# Altium schematic sheet Droplet_SCH.SchDoc(Droplet_SCH)
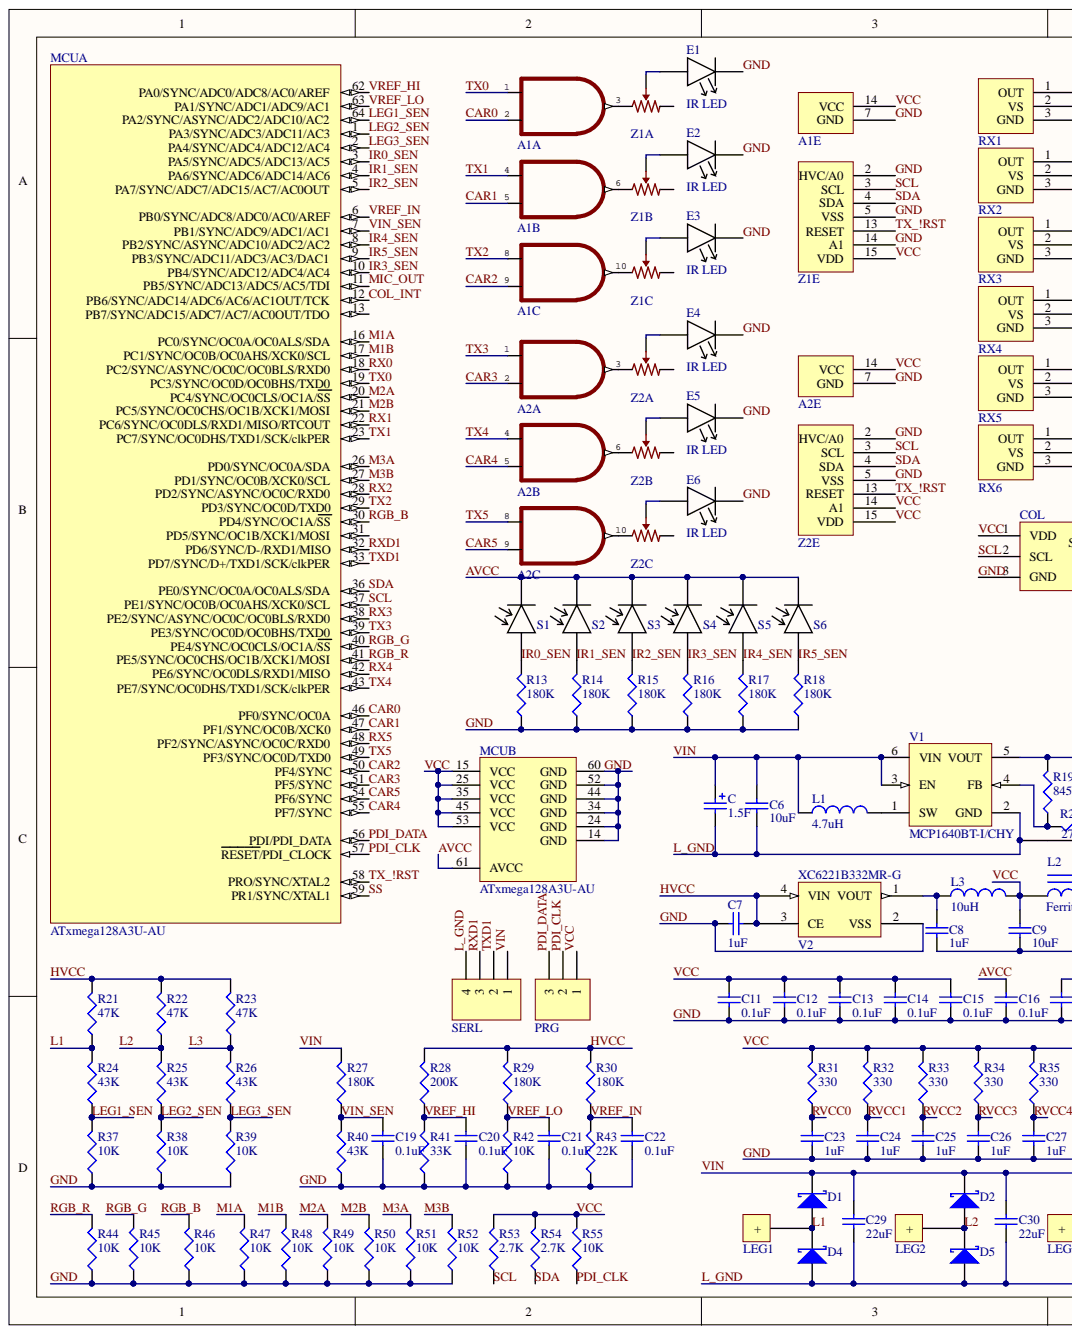
[diagram p0: Droplet_SCH.SchDoc, left]
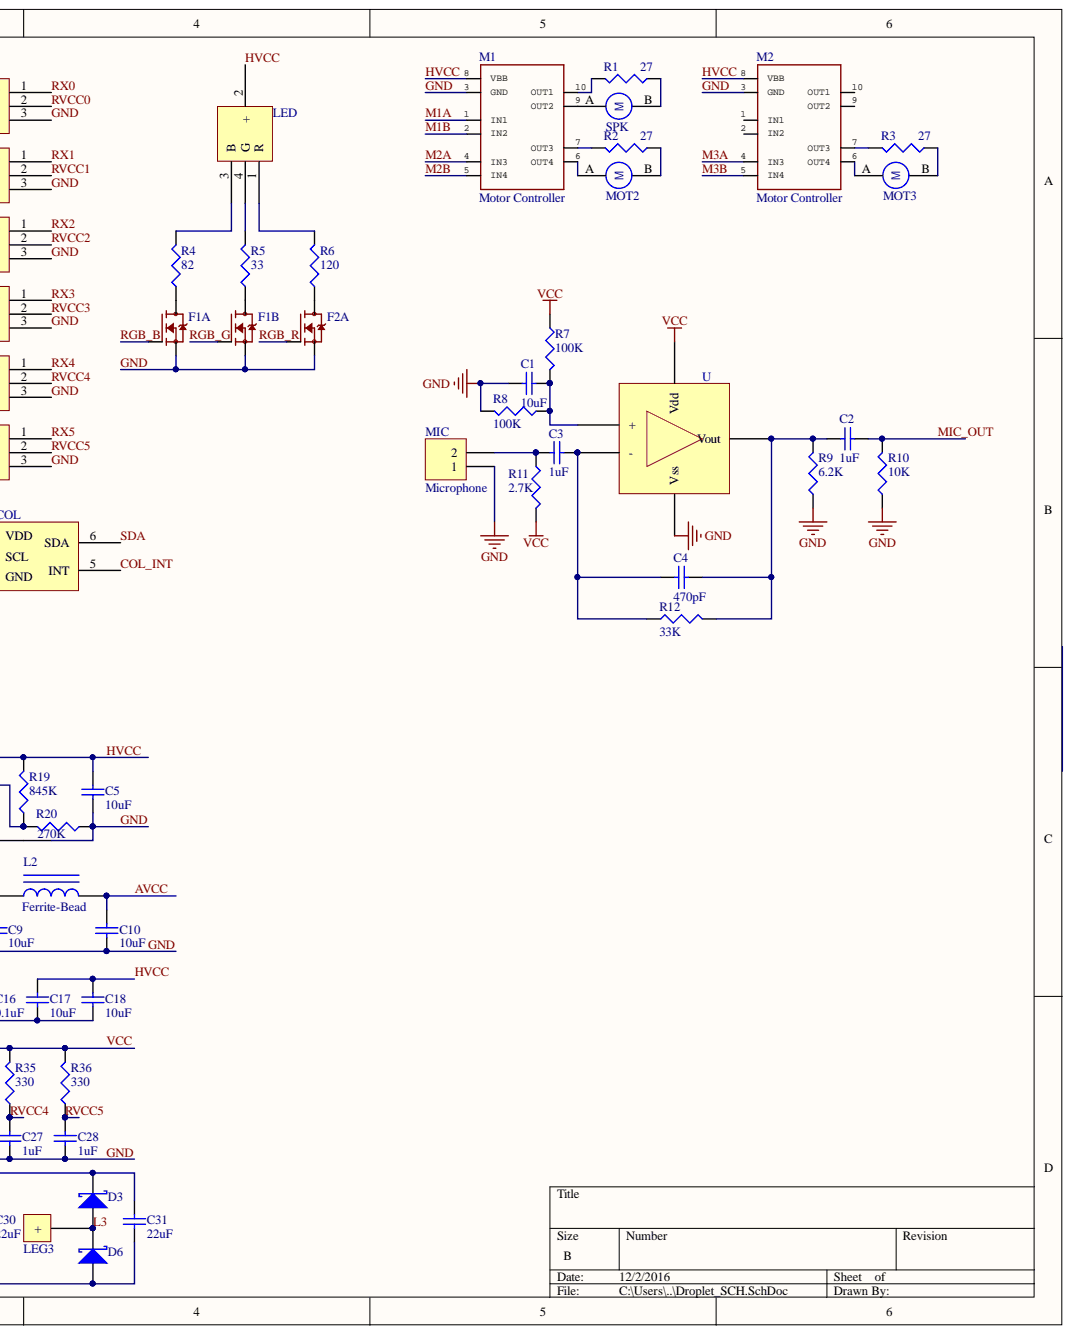
[diagram p1: Droplet_SCH.SchDoc, right]

## Parts
A1  And Gates  [SOT762-1]  (value not drawn)  (parts A,B,C,E)
A2  And Gates  [SOT762-1]  (value not drawn)  (parts A,B,C,E)
C  1.5F  [SuperCap]
C1  10uF  [1608[0603]]
C2  1uF  [0402]
C3  1uF  [0402]
C4  470pF  [0402]
C5  10uF  [1608[0603]]
C6  10uF  [1608[0603]]
C7  1uF  [0402]
C8  1uF  [0402]
C9  10uF  [1608[0603]]
C10  10uF  [1608[0603]]
C11  0.1uF  [0402]
C12  0.1uF  [0402]
C13  0.1uF  [0402]
C14  0.1uF  [0402]
C15  0.1uF  [0402]
C16  0.1uF  [0402]
C17  10uF  [1608[0603]]
C18  10uF  [1608[0603]]
C19  0.1uF  [0402]
C20  0.1uF  [0402]
C21  0.1uF  [0402]
C22  0.1uF  [0402]
C23  1uF  [0402]
C24  1uF  [0402]
C25  1uF  [0402]
C26  1uF  [0402]
C27  1uF  [0402]
C28  1uF  [0402]
C29  22uF  [C1206]
C30  22uF  [C1206]
C31  22uF  [C1206]
COL  Color Sensor  [6SMD-FN]  (value not drawn)
D1  Diode  [Diode]  (value not drawn)
D2  Diode  [Diode]  (value not drawn)
D3  Diode  [Diode]  (value not drawn)
D4  Diode  [Diode]  (value not drawn)
D5  Diode  [Diode]  (value not drawn)
D6  Diode  [Diode]  (value not drawn)
E1  IR LED  [SMD IR RX/TX]
E2  IR LED  [SMD IR RX/TX]
E3  IR LED  [SMD IR RX/TX]
E4  IR LED  [SMD IR RX/TX]
E5  IR LED  [SMD IR RX/TX]
E6  IR LED  [SMD IR RX/TX]
F1  F1  [SOT-363]  (parts A,B)
F2  F2  [SOT-363]  (part A)
GND_<->_L_GND1  Short Circuit  [SHORTCIRCUIT]  (value not drawn)  (no BOM)
L1  4.7uH  [14-1210]
L2  Ferrite-Bead  [1608[0603]]
L3  10uH  [C0805]
LED  RGB LED  [RGB LED]  (value not drawn)
LEG1  Leg  [Wide Leg]  (value not drawn)
LEG2  Leg  [Wide Leg]  (value not drawn)
LEG3  Leg  [Wide Leg]  (value not drawn)
M1  Motor Controller  [EJ 10-PIN DNFN]
M2  Motor Controller  [EJ 10-PIN DNFN]
MCU  ATxmega128A3U-AU  [XMega No Center Pad]  (parts A,B)
MIC  Microphone  [Microphone]
MOT2  Motor  [Motor Pads]  (value not drawn)
MOT3  Motor  [Motor Pads]  (value not drawn)
PRG  Header 3  [3XHEADER_SMT]  (value not drawn)
R1  27  [0402]
R2  27  [0402]
R3  27  [0402]
R4  82  [0402]
R5  33  [0402]
R6  120  [0402]
R7  100K  [0402]
R8  100K  [0402]
R9  6.2K  [0402]
R10  10K  [0402]
R11  2.7K  [0402]
R12  33K  [0402]
R13  180K  [0402]
R14  180K  [0402]
R15  180K  [0402]
R16  180K  [0402]
R17  180K  [0402]
R18  180K  [0402]
R19  845K  [0402]
R20  270K  [0402]
R21  47K  [0402]
R22  47K  [0402]
R23  47K  [0402]
R24  43K  [0402]
R25  43K  [0402]
R26  43K  [0402]
R27  180K  [0402]
R28  200K  [0402]
R29  180K  [0402]
R30  180K  [0402]
R31  330  [0402]
R32  330  [0402]
R33  330  [0402]
R34  330  [0402]
R35  330  [0402]
R36  330  [0402]
R37  10K  [0402]
R38  10K  [0402]
R39  10K  [0402]
R40  43K  [0402]
R41  33K  [0402]
R42  10K  [0402]
R43  22K  [0402]
R44  10K  [0402]
R45  10K  [0402]
R46  10K  [0402]
R47  10K  [0402]
R48  10K  [0402]
R49  10K  [0402]
R50  10K  [0402]
R51  10K  [0402]
R52  10K  [0402]
R53  2.7K  [0402]
R54  2.7K  [0402]
R55  10K  [0402]
RX1  IR Receiver  [IR RECEIVER]  (value not drawn)
RX2  IR Receiver  [IR RECEIVER]  (value not drawn)
RX3  IR Receiver  [IR RECEIVER]  (value not drawn)
RX4  IR Receiver  [IR RECEIVER]  (value not drawn)
RX5  IR Receiver  [IR RECEIVER]  (value not drawn)
RX6  IR Receiver  [IR RECEIVER]  (value not drawn)
S1  IR Sensor  [SMD IR RX/TX]  (value not drawn)
S2  IR Sensor  [SMD IR RX/TX]  (value not drawn)
S3  IR Sensor  [SMD IR RX/TX]  (value not drawn)
S4  IR Sensor  [SMD IR RX/TX]  (value not drawn)
S5  IR Sensor  [SMD IR RX/TX]  (value not drawn)
S6  IR Sensor  [SMD IR RX/TX]  (value not drawn)
SERL  Header 4  [4XHEADER_LONG]  (value not drawn)
SPK  Speaker  [Motor Pads]  (value not drawn)
U  Microchip MCP6001  [OPAMP_SC70]  (value not drawn)
V1  MCP1640BT-I/CHY  [MCHP-SOT-23-CHY6_N]
V2  XC6221B332MR-G  [SOT-25]
Z1  Variable Resistor  [Variable Resistor]  (value not drawn)  (parts A,B,C,E)
Z2  Variable Resistor  [Variable Resistor]  (value not drawn)  (parts A,B,C,E)

## Nets
AVCC: C10.2 C16.2 L2.2 MCU.61(AVCC) S1.2 S2.2 S3.2 S4.2 S5.2 S6.2
CAR0: A1.2 MCU.46(PF0/SYNC/OC0A)
CAR1: A1.5 MCU.47(PF1/SYNC/OC0B/XCK0)
CAR2: A1.9 MCU.50(PF4/SYNC)
CAR3: A2.2 MCU.51(PF5/SYNC)
CAR4: A2.5 MCU.55(PF7/SYNC)
CAR5: A2.9 MCU.54(PF6/SYNC)
COL_INT: COL.5(INT) MCU.12(PB6/SYNC/ADC14/ADC6/AC6/AC1OUT/TCK)
GND: A1.7(GND) A2.7(GND) C1.1 C5.1 C7.1 C8.1 C9.1 C10.1 C11.1 C12.1 C13.1 C14.1 C15.1 C16.1 C17.1 C18.1 C19.1 C20.1 C21.1 C22.1 C23.1 C24.1 C25.1 C26.1 C27.1 C28.1 COL.3(GND) E1.2 E2.2 E3.2 E4.2 E5.2 E6.2 F1.1 F1.4 F2.1 GND_<->_L_GND1.30 M1.3(GND) M2.3(GND) MCU.14(GND) MCU.24(GND) MCU.34(GND) MCU.44(GND) MCU.52(GND) MCU.60(GND) MIC.1 R8.2 R9.1 R10.1 R13.1 R14.1 R15.1 R16.1 R17.1 R18.1 R20.1 R37.1 R38.1 R39.1 R40.1 R41.1 R42.1 R43.1 R44.1 R45.1 R46.1 R47.1 R48.1 R49.1 R50.1 R51.1 R52.1 RX1.3 RX2.3 RX3.3 RX4.3 RX5.3 RX6.3 U.2(Vss) V2.2(VSS) Z1.2(HVC/A0) Z1.5(VSS) Z1.14(A1) Z2.2(HVC/A0) Z2.5(VSS)
HVCC: C5.2 C7.2 C17.2 C18.2 LED.2(+) M1.8(VBB) M2.8(VBB) R19.2 R21.2 R22.2 R23.2 R28.2 R29.2 R30.2 V1.5(VOUT) V2.3(CE) V2.4(VIN)
IR0_SEN: MCU.3(PA5/SYNC/ADC5/ADC13/AC5) R13.2 S1.1
IR1_SEN: MCU.4(PA6/SYNC/ADC6/ADC14/AC6) R14.2 S2.1
IR2_SEN: MCU.5(PA7/SYNC/ADC7/ADC15/AC7/AC0OUT) R15.2 S3.1
IR3_SEN: MCU.10(PB4/SYNC/ADC12/ADC4/AC4) R16.2 S4.1
IR4_SEN: MCU.8(PB2/SYNC/ASYNC/ADC10/ADC2/AC2) R17.2 S5.1
IR5_SEN: MCU.9(PB3/SYNC/ADC11/ADC3/AC3/DAC1) R18.2 S6.1
L1: D1.GND D4.VIN LEG1.1 R21.1 R24.2
L2: D2.GND D5.VIN LEG2.1 R22.1 R25.2
L3: D3.GND D6.VIN LEG3.1 R23.1 R26.2
L_GND: C.GND C6.1 C29.1 C30.1 C31.1 D4.GND D5.GND D6.GND GND_<->_L_GND1.29 SERL.4 V1.2(GND)
LEG1_SEN: MCU.64(PA2/SYNC/ASYNC/ADC2/ADC10/AC2) R24.1 R37.2
LEG2_SEN: MCU.1(PA3/SYNC/ADC3/ADC11/AC3) R25.1 R38.2
LEG3_SEN: MCU.2(PA4/SYNC/ADC4/ADC12/AC4) R26.1 R39.2
M1A: M1.1(IN1) MCU.16(PC0/SYNC/OC0A/OC0ALS/SDA) R47.2
M1B: M1.2(IN2) MCU.17(PC1/SYNC/OC0B/OC0AHS/XCK0/SCL) R48.2
M2A: M1.4(IN3) MCU.20(PC4/SYNC/OC0CLS/OC1A/SS) R49.2
M2B: M1.5(IN4) MCU.21(PC5/SYNC/OC0CHS/OC1B/XCK1/MOSI) R50.2
M3A: M2.4(IN3) MCU.26(PD0/SYNC/OC0A/SDA) R51.2
M3B: M2.5(IN4) MCU.27(PD1/SYNC/OC0B/XCK0/SCL) R52.2
MIC_OUT: C2.2 MCU.11(PB5/SYNC/ADC13/ADC5/AC5/TDI) R10.2
PDI_CLK: MCU.57(RESET/PDI_CLOCK) PRG.2 R55.1
PDI_DATA: MCU.56(PDI/PDI_DATA) PRG.3
RGB_B: F1.2 MCU.30(PD4/SYNC/OC1A/SS) R46.2
RGB_G: F1.5 MCU.40(PE4/SYNC/OC0CLS/OC1A/SS) R45.2
RGB_R: F2.2 MCU.41(PE5/SYNC/OC0CHS/OC1B/XCK1/MOSI) R44.2
RVCC0: C23.2 R31.1 RX1.2
RVCC1: C24.2 R32.1 RX2.2
RVCC2: C25.2 R33.1 RX3.2
RVCC3: C26.2 R34.1 RX4.2
RVCC4: C27.2 R35.1 RX5.2
RVCC5: C28.2 R36.1 RX6.2
RX0: MCU.18(PC2/SYNC/ASYNC/OC0C/OC0BLS/RXD0) RX1.1
RX1: MCU.22(PC6/SYNC/OC0DLS/RXD1/MISO/RTCOUT) RX2.1
RX2: MCU.28(PD2/SYNC/ASYNC/OC0C/RXD0) RX3.1
RX3: MCU.38(PE2/SYNC/ASYNC/OC0C/OC0BLS/RXD0) RX4.1
RX4: MCU.42(PE6/SYNC/OC0DLS/RXD1/MISO) RX5.1
RX5: MCU.48(PF2/SYNC/ASYNC/OC0C/RXD0) RX6.1
RXD1: MCU.32(PD6/SYNC/D-/RXD1/MISO) SERL.3
SCL: COL.2(SCL) MCU.37(PE1/SYNC/OC0B/OC0AHS/XCK0/SCL) R53.1 Z1.3(SCL) Z2.3(SCL)
SDA: COL.6(SDA) MCU.36(PE0/SYNC/OC0A/OC0ALS/SDA) R54.1 Z1.4(SDA) Z2.4(SDA)
SS: MCU.59(PR1/SYNC/XTAL1)
TX0: A1.1 MCU.19(PC3/SYNC/OC0D/OC0BHS/TXD0)
TX1: A1.4 MCU.23(PC7/SYNC/OC0DHS/TXD1/SCK/clkPER)
TX2: A1.8 MCU.29(PD3/SYNC/OC0D/TXD0)
TX3: A2.1 MCU.39(PE3/SYNC/OC0D/OC0BHS/TXD0)
TX4: A2.4 MCU.43(PE7/SYNC/OC0DHS/TXD1/SCK/clkPER)
TX5: A2.8 MCU.49(PF3/SYNC/OC0D/TXD0)
TX_!RST: MCU.58(PRO/SYNC/XTAL2) Z1.13(RESET) Z2.13(RESET)
TXD1: MCU.33(PD7/SYNC/D+/TXD1/SCK/clkPER) SERL.2
VCC: A1.14(VCC) A2.14(VCC) C9.2 C11.2 C12.2 C13.2 C14.2 C15.2 COL.1(VDD) L2.1 L3.2 MCU.15(VCC) MCU.25(VCC) MCU.35(VCC) MCU.45(VCC) MCU.53(VCC) PRG.1 R7.1 R11.2 R31.2 R32.2 R33.2 R34.2 R35.2 R36.2 R53.2 R54.2 R55.2 U.5(Vdd) Z1.15(VDD) Z2.14(A1) Z2.15(VDD)
VIN: C.+ C6.2 C29.2 C30.2 C31.2 D1.VIN D2.VIN D3.VIN L1.1 R27.2 SERL.1 V1.3(EN) V1.6(VIN)
VIN_SEN: C19.2 MCU.7(PB1/SYNC/ADC9/ADC1/AC1) R27.1 R40.2
VREF_HI: C20.2 MCU.62(PA0/SYNC/ADC0/ADC8/AC0/AREF) R28.1 R41.2
VREF_IN: C22.2 MCU.6(PB0/SYNC/ADC8/ADC0/AC0/AREF) R30.1 R43.2
VREF_LO: C21.2 MCU.63(PA1/SYNC/ADC1/ADC9/AC1) R29.1 R42.2
NetA1_3: A1.3 Z1.9
NetA1_6: A1.6 Z1.8
NetA1_10: A1.10 Z1.18
NetA2_3: A2.3 Z2.9
NetA2_6: A2.6 Z2.8
NetA2_10: A2.10 Z2.18
NetC1_2: C1.2 R7.2 R8.1 U.3(+)
NetC2_1: C2.1 C4.2 R9.2 R12.2 U.1(Vout)
NetC3_1: C3.1 MIC.2 R11.1
NetC3_2: C3.2 C4.1 R12.1 U.4(-)
NetC8_2: C8.2 L3.1 V2.1(VOUT)
NetE1_1: E1.1 Z1.10
NetE2_1: E2.1 Z1.7
NetE3_1: E3.1 Z1.17
NetE4_1: E4.1 Z2.10
NetE5_1: E5.1 Z2.7
NetE6_1: E6.1 Z2.17
NetF1_3: F1.3 R5.1
NetF1_6: F1.6 R4.1
NetF2_6: F2.6 R6.1
NetL1_2: L1.2 V1.1(SW)
NetLED_1: LED.1(R) R6.2
NetLED_3: LED.3(B) R4.2
NetLED_4: LED.4(G) R5.2
NetM1_6: M1.6(OUT4) MOT2.A
NetM1_7: M1.7(OUT3) R2.2
NetM1_9: M1.9(OUT2) SPK.A
NetM1_10: M1.10(OUT1) R1.2
NetM2_6: M2.6(OUT4) MOT3.A
NetM2_7: M2.7(OUT3) R3.2
NetMOT2_B: MOT2.B R2.1
NetMOT3_B: MOT3.B R3.1
NetR1_1: R1.1 SPK.B
NetR19_1: R19.1 R20.2 V1.4(FB)
N00031:

Not connected: M2 1(IN1) 2(IN2) 9(OUT2) 10(OUT1); MCU 13(PB7/SYNC/ADC15/ADC7/AC7/AC0OUT/TDO) 31(PD5/SYNC/OC1B/XCK1/MOSI); Z1 6 11 16; Z2 6 11 16

## Library data of the parts
A1 A2 = And Gates: 74LVC08ABQ,115; Manufacturer: NXP Semiconductors; Component Kind: Standard; Digikey Part #: 568-2993-1-ND; Library Name: Schlib1.SchLib; PackageReference: SOT762-1
C = Supercap: PM-5R0H155-R; Manufacturer: Eaton Bussmann; Component Kind: Standard; Digikey Part #: 283-3016-ND; Library Name: Schlib1.SchLib; Value: 1.5F
C1 C5 C6 C9 C10 C17 C18 = Cap Semi: LMK107BBJ106MALT; Manufacturer: Taiyo Yuden; Component Kind: Standard; Digikey Part #: 587-3258-2-ND; LatestRevisionDate: 29-May-2009; LatestRevisionNote: IPC-7351 Footprint Added.; Library Name: Miscellaneous Devices.IntLib; PackageDescription: Chip Capacitor; PackageReference: 0603 (1608 Metric); Published: 8-Jun-2000; Signal Integrity: Cap Semi; Simulation: CAP; Value: 10uF; Publisher: Altium Limited
C2 C3 C7 C8 C23-C28 = Cap Semi: LMK105BJ105KV-F; Manufacturer: Taiyo Yuden; Component Kind: Standard; Digikey Part #: 587-1454-2-ND; LatestRevisionDate: 29-May-2009; LatestRevisionNote: IPC-7351 Footprint Added.; Library Name: Miscellaneous Devices.IntLib; PackageDescription: Chip Capacitor; PackageReference: 0402 (1005 Metric); Published: 8-Jun-2000; Signal Integrity: Cap Semi; Simulation: CAP; Value: 1uF; Publisher: Altium Limited
C4 = Cap Semi: UMK105B7471KV-F; Manufacturer: Taiyo Yuden; Component Kind: Standard; Digikey Part #: 587-1219-1-ND; LatestRevisionDate: 29-May-2009; LatestRevisionNote: IPC-7351 Footprint Added.; Library Name: Miscellaneous Devices.IntLib; PackageDescription: Chip Capacitor; PackageReference: 0402 (1005 Metric); Published: 8-Jun-2000; Signal Integrity: Cap Semi; Simulation: CAP; Value: 470pF; Publisher: Altium Limited
C11-C16 C19-C22 = Cap Semi: LMK105BJ104KV-F; Manufacturer: Taiyo Yuden; Component Kind: Standard; Digikey Part #: 587-1227-2-ND; LatestRevisionDate: 29-May-2009; LatestRevisionNote: IPC-7351 Footprint Added.; Library Name: Miscellaneous Devices.IntLib; PackageDescription: Chip Capacitor; PackageReference: 0402 (1005 Metric); Published: 8-Jun-2000; Signal Integrity: Cap Semi; Simulation: CAP; Value: 0.1uF; Publisher: Altium Limited
C29-C31 = Cap Semi: LMK316AB7226ML-TR; Manufacturer: Taiyo Yuden; Component Kind: Standard; Digikey Part #: 587-2782-2-ND; LatestRevisionDate: 29-May-2009; LatestRevisionNote: IPC-7351 Footprint Added.; Library Name: Miscellaneous Devices.IntLib; PackageDescription: Chip Capacitor; PackageReference: C1206; Published: 8-Jun-2000; Signal Integrity: Cap Semi; Simulation: CAP; Value: 22uF; Publisher: Altium Limited
COL = Color Sensor: Manufacturer: AMS-TAOS USA Inc; Component Kind: Standard; Digikey Part #: TCS34725FNCT-ND; Library Name: Schlib1.SchLib; PackageReference: 6-SMD Module
D1-D6 = Diode: PMEG2010ER,115; Manufacturer: NXP Semiconductors; Component Kind: Standard; Digikey Part #: 568-6504-2-ND; Library Name: Schlib1.SchLib; PackageReference: SOD-123W
E1-E6 = IR LED: APA3010F3C-GX; Manufacturer: Kingbright; Component Kind: Standard; Digikey Part #: 754-1578-2-ND; Library Name: Schlib1.SchLib
F1 F2 = F1: NTJD4401NT1G; Manufacturer: ON Semiconductor; Component Kind: Standard; Digikey Part #: NTJD4401NT1GOSTR-ND; Library Name: Schlib1.SchLib; PackageReference: SOT-363
L1 = Inductor: BRL3225T4R7M; Manufacturer: Taiyo Yuden; Code_IEC: 1005; Code_JEITA: 0402; Component Kind: Standard; Digikey Part #: 587-2166-2-ND; LatestRevisionDate: 29-May-2009; LatestRevisionNote: IPC-7351 Footprint Added.; Library Name: Miscellaneous Devices.IntLib; PackageDescription: Chip Inductor; PackageReference: 1210 (3225 Metric); Published: 8-Jun-2000; Signal Integrity: Inductor; Simulation: INDUCTOR; Value: 4.7uH; Publisher: Altium Limited
L2 = Inductor Iron: 74279263; Manufacturer: Wurth Electronics Inc; Value: 220 Ohm @ 100MHz, 500mA; Component Kind: Standard; Digikey Part #: 732-1581-1-ND; Library Name: Miscellaneous Devices.IntLib; PackageReference: 0603 (1608 Metric); Signal Integrity: Inductor Iron; Simulation: INDUCTOR
L3 = Inductor: CB2012T100KR; Manufacturer: Taiyo Yuden; Code_IEC: 1005; Code_JEITA: 0402; Component Kind: Standard; Digikey Part #: 587-2452-2-ND; LatestRevisionDate: 29-May-2009; LatestRevisionNote: IPC-7351 Footprint Added.; Library Name: Miscellaneous Devices.IntLib; PackageDescription: Chip Inductor; PackageReference: 0805 (2012 Metric); Published: 8-Jun-2000; Signal Integrity: Inductor; Simulation: INDUCTOR; Value: 10uH; Publisher: Altium Limited
LED = RGB LED: CLV1A-FKB-CJ1M1F1BB7R4S3; Manufacturer: Cree Inc; Component Kind: Standard; Digikey Part #: CLV1A-FKB-CJ1M1F1BB7R4S3TR-ND; Library Name: Schlib1.SchLib; PackageReference: 4-PLCC
LEG1-LEG3 = Leg: WM50007-24-ND; Manufacturer: Molex Inc; Component Kind: Standard; Digikey Part #: WM50007-24-ND; Library Name: Schlib1.SchLib
M1 M2 = Motor Controller: A3901SEJTR-T; Manufacturer: Allegro MicroSystems, LLC; Component Kind: Standard; Digikey Part #: 620-1159-2-ND; Library Name: Schlib1.SchLib; PackageReference: 10-WFDFN Exposed Pad
MCU = ATxmega128A3U-AU: ATXMEGA128A3U-MH; Manufacturer: Atmel; Code_JEDEC: MS-026-AEB; Component Kind: Standard; ComponentLink1Description: Manufacturer URL; ComponentLink1URL: http://www.atmel.com/; ComponentLink2Description: ATxmega64A3U/128A3U/192A3U/256A3U Datasheet; ComponentLink2URL: http://www.atmel.com/dyn/resources/prod_documents/doc8386.pdf; DatasheetVersion: revB, Dec-2011; Digikey Part #: ATXMEGA128A3U-MH-ND; Library Name: Atmel Microcontroller AVR XMEGA.IntLib; PackageDescription: 64-Pin Plastic Thin Profile Quad Flat Pack, 14 x 14 x 1.0 mm Body, 0.8 mm Pitch (TQFP); PackageReference: 64-VFQFN Exposed Pad; PackageVersion: revC, Oct-2010
MIC = Header 2: CMC-2242PBL-A; Manufacturer: CUI Inc; Component Kind: Standard; Digikey Part #: 102-1727-ND; LatestRevisionDate: 17-Jul-2002; LatestRevisionNote: Re-released for DXP Platform.; Library Name: Miscellaneous Connectors.IntLib; Signal Integrity: Connector; Publisher: Altium Limited
MOT2 MOT3 = Motor: Manufacturer: Parallax, Inc; Component Kind: Standard; Digikey Part #: 28821-ND; Library Name: Schlib1.SchLib
PRG = Header 3: NPTC031KFXC-RC; Manufacturer: Sullins Connector Sollutions; Component Kind: Standard; Digikey Part #: S5595-ND; LatestRevisionDate: 17-Jul-2002; LatestRevisionNote: Re-released for DXP Platform.; Library Name: Miscellaneous Connectors.IntLib; PackageReference: 3XHEADER_SMT; Signal Integrity: Connector; Publisher: Altium Limited
R1-R3 = Res3: RC0402JR-0727RL; Manufacturer: Yageo; Component Kind: Standard; Digikey Part #: 311-27JRCT-ND; LatestRevisionDate: 29-May-2009; LatestRevisionNote: IPC-7351 Footprint Added.; Library Name: Miscellaneous Devices.IntLib; PackageDescription: Chip Resistor; PackageReference: 0402 (1005 Metric); Published: 8-Jun-2000; Signal Integrity: Res; Simulation: RESISTOR; Value: 27; Publisher: Altium Limited
R4 = Res3: RC0402JR-0782RL; Manufacturer: Yageo; Component Kind: Standard; Digikey Part #: 311-82JRCT-ND; LatestRevisionDate: 29-May-2009; LatestRevisionNote: IPC-7351 Footprint Added.; Library Name: Miscellaneous Devices.IntLib; PackageDescription: Chip Resistor; PackageReference: 0402 (1005 Metric); Published: 8-Jun-2000; Signal Integrity: Res; Simulation: RESISTOR; Value: 82; Publisher: Altium Limited
R5 = Res3: RMCF0402JT33R0; Manufacturer: Stackpole Electronics Inc; Component Kind: Standard; Digikey Part #: RMCF0402JT33R0TR-ND; LatestRevisionDate: 29-May-2009; LatestRevisionNote: IPC-7351 Footprint Added.; Library Name: Miscellaneous Devices.IntLib; PackageDescription: Chip Resistor; PackageReference: 0402 (1005 Metric); Published: 8-Jun-2000; Signal Integrity: Res; Simulation: RESISTOR; Value: 33; Publisher: Altium Limited
R6 = Res3: RMCF0402JT120R; Manufacturer: Stackpole Electronics Inc; Component Kind: Standard; Digikey Part #: RMCF0402JT120RTR-ND; LatestRevisionDate: 29-May-2009; LatestRevisionNote: IPC-7351 Footprint Added.; Library Name: Miscellaneous Devices.IntLib; PackageDescription: Chip Resistor; PackageReference: 0402 (1005 Metric); Published: 8-Jun-2000; Signal Integrity: Res; Simulation: RESISTOR; Value: 120; Publisher: Altium Limited
R7 R8 = Res3: RMCF0402JT100K; Manufacturer: Stackpole Electronics Inc; Component Kind: Standard; Digikey Part #: RMCF0402JT100KTR-ND; LatestRevisionDate: 29-May-2009; LatestRevisionNote: IPC-7351 Footprint Added.; Library Name: Miscellaneous Devices.IntLib; PackageDescription: Chip Resistor; PackageReference: 0402 (1005 Metric); Published: 8-Jun-2000; Signal Integrity: Res; Simulation: RESISTOR; Value: 100K; Publisher: Altium Limited
R9 = Res3: RMCF0402JT6K20; Manufacturer: Stackpole Electronics Inc; Component Kind: Standard; Digikey Part #: RMCF0402JT6K20TR-ND; LatestRevisionDate: 29-May-2009; LatestRevisionNote: IPC-7351 Footprint Added.; Library Name: Miscellaneous Devices.IntLib; PackageDescription: Chip Resistor; PackageReference: 0402 (1005 Metric); Published: 8-Jun-2000; Signal Integrity: Res; Simulation: RESISTOR; Value: 6.2K; Publisher: Altium Limited
R10 R37-R39 R42 R44-R55 = Res3: RMCF0402JT10K0; Manufacturer: Stackpole Electronics Inc; Component Kind: Standard; LatestRevisionDate: 29-May-2009; LatestRevisionNote: IPC-7351 Footprint Added.; Library Name: Miscellaneous Devices.IntLib; PackageDescription: Chip Resistor; PackageReference: 0402 (1005 Metric); Published: 8-Jun-2000; Signal Integrity: Res; Simulation: RESISTOR; Publisher: Altium Limited
  R10 R37-R39 R42 R44-R52 R55: Digikey Part #: RMCF0402JT10K0TR-ND; Value: 10K
  R53 R54: Digikey Part #: RMCF0402JT2K70CT-ND; Value: 2.7K
R11 = Res3: RMCF0402JT2K70; Manufacturer: Stackpole Electronics Inc; Component Kind: Standard; Digikey Part #: RMCF0402JT2K70TR-ND; LatestRevisionDate: 29-May-2009; LatestRevisionNote: IPC-7351 Footprint Added.; Library Name: Miscellaneous Devices.IntLib; PackageDescription: Chip Resistor; PackageReference: 0402 (1005 Metric); Published: 8-Jun-2000; Signal Integrity: Res; Simulation: RESISTOR; Value: 2.7K; Publisher: Altium Limited
R12 R41 = Res3: RMCF0402JT33K0; Manufacturer: Stackpole Electronics Inc; Component Kind: Standard; Digikey Part #: RMCF0402JT33K0TR-ND; LatestRevisionDate: 29-May-2009; LatestRevisionNote: IPC-7351 Footprint Added.; Library Name: Miscellaneous Devices.IntLib; PackageDescription: Chip Resistor; PackageReference: 0402 (1005 Metric); Published: 8-Jun-2000; Signal Integrity: Res; Simulation: RESISTOR; Value: 33K; Publisher: Altium Limited
R13-R18 R27 R29 R30 = Res3: RMCF0402JT180K; Manufacturer: Stackpole Electronics Inc; Component Kind: Standard; Digikey Part #: RMCF0402JT180KTR-ND; LatestRevisionDate: 29-May-2009; LatestRevisionNote: IPC-7351 Footprint Added.; Library Name: Miscellaneous Devices.IntLib; PackageDescription: Chip Resistor; PackageReference: 0402 (1005 Metric); Published: 8-Jun-2000; Signal Integrity: Res; Simulation: RESISTOR; Value: 180K; Publisher: Altium Limited
R19 = Res3: RMCF0402FT845K; Manufacturer: Stackpole Electronics Inc; Component Kind: Standard; Digikey Part #: RMCF0402FT845KTR-ND; LatestRevisionDate: 29-May-2009; LatestRevisionNote: IPC-7351 Footprint Added.; Library Name: Miscellaneous Devices.IntLib; PackageDescription: Chip Resistor; PackageReference: 0402 (1005 Metric); Published: 8-Jun-2000; Signal Integrity: Res; Simulation: RESISTOR; Value: 845K; Publisher: Altium Limited
R20 = Res3: RMCF0402JT270K; Manufacturer: Stackpole Electronics Inc; Component Kind: Standard; Digikey Part #: RMCF0402JT270KTR-ND; LatestRevisionDate: 29-May-2009; LatestRevisionNote: IPC-7351 Footprint Added.; Library Name: Miscellaneous Devices.IntLib; PackageDescription: Chip Resistor; PackageReference: 0402 (1005 Metric); Published: 8-Jun-2000; Signal Integrity: Res; Simulation: RESISTOR; Value: 270K; Publisher: Altium Limited
R21-R23 = Res3: RMCF0402JT47K0; Manufacturer: Stackpole Electronics Inc; Component Kind: Standard; Digikey Part #: RMCF0402JT47K0TR-ND; LatestRevisionDate: 29-May-2009; LatestRevisionNote: IPC-7351 Footprint Added.; Library Name: Miscellaneous Devices.IntLib; PackageDescription: Chip Resistor; PackageReference: 0402 (1005 Metric); Published: 8-Jun-2000; Signal Integrity: Res; Simulation: RESISTOR; Value: 47K; Publisher: Altium Limited
R24-R26 R40 = Res3: RMCF0402JT43K0; Manufacturer: Stackpole Electronics Inc; Component Kind: Standard; Digikey Part #: RMCF0402JT43K0TR-ND; LatestRevisionDate: 29-May-2009; LatestRevisionNote: IPC-7351 Footprint Added.; Library Name: Miscellaneous Devices.IntLib; PackageDescription: Chip Resistor; PackageReference: 0402 (1005 Metric); Published: 8-Jun-2000; Signal Integrity: Res; Simulation: RESISTOR; Value: 43K; Publisher: Altium Limited
R28 = Res3: RC0402FR-07200KL; Manufacturer: Yageo; Component Kind: Standard; Digikey Part #: 311-200KLRTR-ND; LatestRevisionDate: 29-May-2009; LatestRevisionNote: IPC-7351 Footprint Added.; Library Name: Miscellaneous Devices.IntLib; PackageDescription: Chip Resistor; PackageReference: 0402 (1005 Metric); Published: 8-Jun-2000; Signal Integrity: Res; Simulation: RESISTOR; Value: 200K; Publisher: Altium Limited
R31-R36 = Res3: RMCF0402JT330R; Manufacturer: Stackpole Electronics Inc; Component Kind: Standard; Digikey Part #: RMCF0402JT330RTR-ND; LatestRevisionDate: 29-May-2009; LatestRevisionNote: IPC-7351 Footprint Added.; Library Name: Miscellaneous Devices.IntLib; PackageDescription: Chip Resistor; PackageReference: 0402 (1005 Metric); Published: 8-Jun-2000; Signal Integrity: Res; Simulation: RESISTOR; Value: 330; Publisher: Altium Limited
R43 = Res3: RC0402FR-0722KL; Manufacturer: Yageo; Component Kind: Standard; Digikey Part #: 311-22.0KLRTR-ND; LatestRevisionDate: 29-May-2009; LatestRevisionNote: IPC-7351 Footprint Added.; Library Name: Miscellaneous Devices.IntLib; PackageDescription: Chip Resistor; PackageReference: 0402 (1005 Metric); Published: 8-Jun-2000; Signal Integrity: Res; Simulation: RESISTOR; Value: 22K; Publisher: Altium Limited
RX1-RX6 = IR Receiver: TSSP4038; Manufacturer: Vishay Semiconductor Opto Division; Component Kind: Standard; Digikey Part #: TSSP4038-ND; Library Name: Schlib1.SchLib
S1-S6 = IR Sensor: APECVA3010P3BT; Manufacturer: Kingbright; Component Kind: Standard; Digikey Part #: 754-1365-2-ND; Library Name: Schlib1.SchLib
SERL = Header 4: SSA-104-W-T; Manufacturer: Samtec Inc; Component Kind: Standard; Digikey Part #: SAM1125-04-ND; LatestRevisionDate: 17-Jul-2002; LatestRevisionNote: Re-released for DXP Platform.; Library Name: Miscellaneous Connectors.IntLib; Signal Integrity: Connector; Publisher: Altium Limited
SPK = Motor: Manufacturer: CUI Inc; Component Kind: Standard; Digikey Part #: 102-2493-ND; Library Name: Schlib1.SchLib
U = Microchip MCP6001: MCP6001T-I/LT; Component Kind: Standard; Digikey Part #: MCP6001T-I/LTCT-ND; Digikey Part Number: MCP6001T-I/LTCT-ND; Library Name: ComponentLibrary.SchLib; Manufacturer: Microchip; Manufacturer Part Number: MCP6001T-I/LT; PackageReference: SOT-753
V1 = MCP1640BT-I/CHY: Manufacturer: Microchip Technology; Component Kind: Standard; ComponentLink1Description: Manufacturer URL; ComponentLink1URL: http://www.microchip.com; ComponentLink2Description: Datasheet; ComponentLink2URL: http://ww1.microchip.com/downloads/en/DeviceDoc/22234B.pdf; ComponentLink3Description: Package Specification; ComponentLink3URL: http://www.microchip.com/stellent/groups/techpub_sg/documents/packagingspec/en012702.pdf; ComponentLink4Description: Product URL; ComponentLink4URL: http://www.microchip.com/MCP1640; DatasheetVersion: Rev. B; Description: MCP1640BT-I/CHY; Digikey Part #: MCP1640BT-I/CHYTR-ND; Library Name: Microchip Power Management Switching Regulator.IntLib; Mounting Technology: Surface Mount; PackageDescription: 6-Lead Plastic Small Outline Transistor, 2.9 x 1.55 mm Body, 0.95 mm Pitch; PackageReference: SOT-23-6; PackageVersion: C04-028B; PartNumber: MCP1640BT-I/CHY; Supplier 1: Digi-Key; Supplier 2: Digi-Key; Supplier 3: Digi-Key; Supplier 4: Farnell; Supplier 5: Newark; Supplier 6: Newark; Supplier 7: Mouser; Supplier Part Number 1: MCP1640BT-I/CHYTR-ND; Supplier Part Number 2: MCP1640BT-I/CHYCT-ND; Supplier Part Number 3: MCP1640BT-I/CHYDKR-ND; Supplier Part Number 4: 1800207; Supplier Part Number 5: 73R3625; Supplier Part Number 6: 24R6820; Supplier Part Number 7: 579-MCP1640BT-I/CHY
V2 = V1: Manufacturer: Torex Semiconductor Ltd; Component Kind: Standard; Description: XC6221B332MR-G; Digikey Part #: 893-1101-2-ND; Library Name: Schlib1.SchLib; PackageReference: SOT-25
Z1 Z2 = Variable Resistor: MCP4451-104E/ML; Manufacturer: Microchip Technology; Component Kind: Standard; Digikey Part #: MCP4451-104E/ML-ND; Library Name: Schlib1.SchLib; PackageReference: 20-QFN (4x4)
GND_<->_L_GND1 = Short Circuit: Library Name: Schlib1.SchLib
